# Altium schematic sheet Carte_Batterie PAMI 2024.SchDoc("Carte_Batterie PAMI 2024")
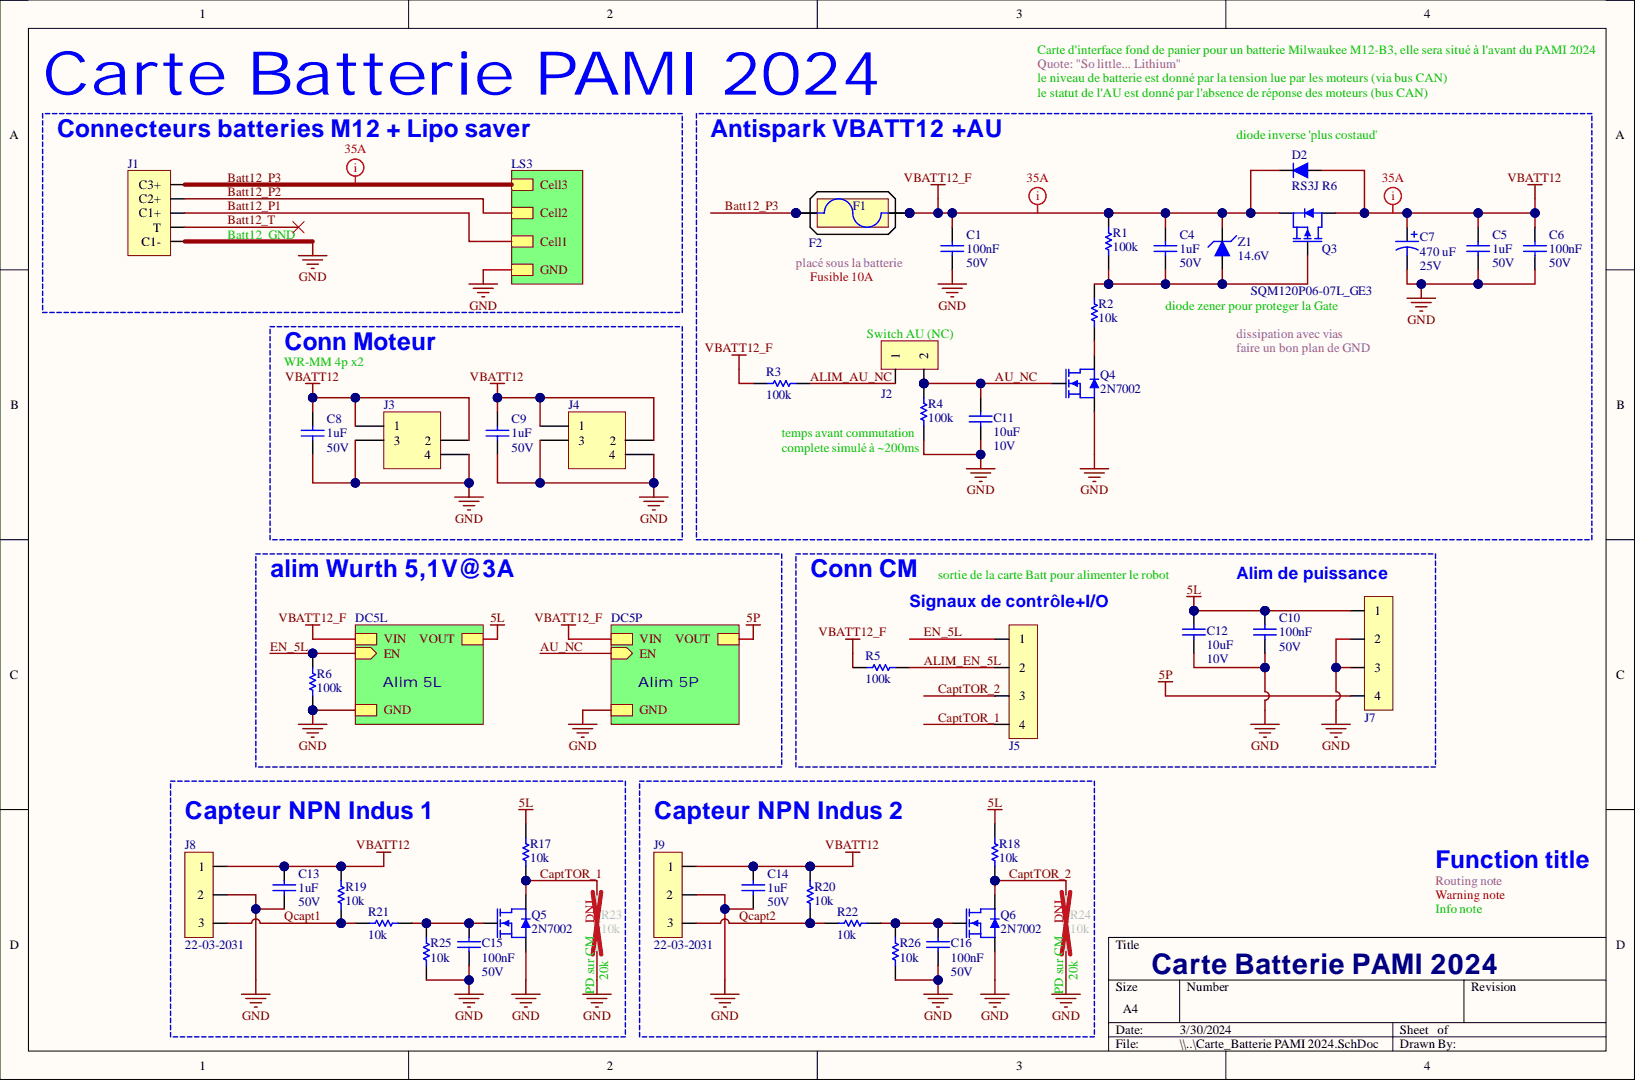
[diagram p0: Carte_Batterie PAMI 2024.SchDoc]

## Parts
C1  100nF  RatedVoltage: 50V  [WCAP-CSGP_0603, 1.6x0.8x0.8]
C4  1uF  RatedVoltage: 50V  [WCAP-CSGP_0805, 2x1.25x1.25]
C5  1uF  RatedVoltage: 50V  [WCAP-CSGP_0805, 2x1.25x1.25]
C6  100nF  RatedVoltage: 50V  [WCAP-CSGP_0603, 1.6x0.8x0.8]
C7  470 uF  RatedVoltage: 25V  [WCAP-ATG8_8x11.5x3.5x0.5]
C8  1uF  RatedVoltage: 50V  [WCAP-CSGP_0805, 2x1.25x1.25]
C9  1uF  RatedVoltage: 50V  [WCAP-CSGP_0805, 2x1.25x1.25]
C10  100nF  RatedVoltage: 50V  [WCAP-CSGP_0603, 1.6x0.8x0.8]
C11  10uF  RatedVoltage: 10V  [WCAP-CSGP_0805, 2x1.25x1.25]
C12  10uF  RatedVoltage: 10V  [WCAP-CSGP_0805, 2x1.25x1.25]
C13  1uF  RatedVoltage: 50V  [WCAP-CSGP_0805, 2x1.25x1.25]
C14  1uF  RatedVoltage: 50V  [WCAP-CSGP_0805, 2x1.25x1.25]
C15  100nF  RatedVoltage: 50V  [WCAP-CSGP_0603, 1.6x0.8x0.8]
C16  100nF  RatedVoltage: 50V  [WCAP-CSGP_0603, 1.6x0.8x0.8]
D2  RS3J R6  [SMC, DO-214AB]
F1  0891030.NXS  (value not drawn)  (mechanical)
F2  3557-2  [3557-2]  (value not drawn)
J1  custom connector  [CONN_BATT-M12]  (value not drawn)
J2  22-03-2021  [Conn_22-03-2021]  (value not drawn)
J3  value 4  [690367280476]  (hidden comment: 690367280476)
J4  value 4  [690367280476]  (hidden comment: 690367280476)
J5  22-03-2041  [Conn_22-03-2041]  (value not drawn)
J7  22-03-2041  [Conn_22-03-2041]  (value not drawn)
J8  22-03-2031  [Conn_22-03-2031]
J9  22-03-2031  [Conn_22-03-2031]
Q3  SQM120P06-07L_GE3  [TO263AB, D2PAK]
Q4  2N7002  [SOT-23]
Q5  2N7002  [SOT-23]
Q6  2N7002  [SOT-23]
R1  value 100k  [RESC1608X06N]  (hidden comment: RC0603FR-07100KL)
R2  value 10k  [RESC1608X06N]  (hidden comment: RC0603FR-0710KL)
R3  value 100k  [RESC1608X06N]  (hidden comment: RC0603FR-07100KL)
R4  value 100k  [RESC1608X06N]  (hidden comment: RC0603FR-07100KL)
R5  value 100k  [RESC1608X06N]  (hidden comment: RC0603FR-07100KL)
R6  value 100k  [RESC1608X06N]  (hidden comment: RC0603FR-07100KL)
R17  value 10k  [RESC1608X06N]  (hidden comment: RC0603FR-0710KL)
R18  value 10k  [RESC1608X06N]  (hidden comment: RC0603FR-0710KL)
R19  value 10k  [RESC1608X06N]  (hidden comment: RC0603FR-0710KL)
R20  value 10k  [RESC1608X06N]  (hidden comment: RC0603FR-0710KL)
R21  value 10k  [RESC1608X06N]  (hidden comment: RC0603FR-0710KL)
R22  value 10k  [RESC1608X06N]  (hidden comment: RC0603FR-0710KL)
R23  value 10k  [RESC1608X06N]  (hidden comment: RC0603FR-0710KL)
R24  value 10k  [RESC1608X06N]  (hidden comment: RC0603FR-0710KL)
R25  value 10k  [RESC1608X06N]  (hidden comment: RC0603FR-0710KL)
R26  value 10k  [RESC1608X06N]  (hidden comment: RC0603FR-0710KL)
Z1  value 14.6V  [SOD-323]  (hidden comment: MM3Z15VST1G)

## Nets
5L: C10.2 C12.2 J7.1 R17.2 R18.2
5P: J7.4
GND: C1.1 C5.1 C6.1 C7.2 C8.1 C9.1 C10.1 C11.1 C12.1 C13.1 C14.1 C15.2 C16.2 J1.5(C1-) J3.3 J3.4 J4.3 J4.4 J7.2 J7.3 J8.2 J9.2 Q4.2 Q5.2 Q6.2 R4.1 R6.1 R23.1 R24.1 R25.1 R26.1
VBATT12: C5.2 C6.2 C7.1 C8.2 C9.2 C13.2 C14.2 D2.1 J3.1 J3.2 J4.1 J4.2 J8.1 J9.1 Q3.4 R19.2 R20.2
VBATT12_F: C1.2 C4.2 D2.2 F2.2 F2.4 Q3.3 R1.2 R3.1 R5.1 Z1.2
ALIM_AU_NC: J2.1 R3.2
ALIM_EN_5L: J5.2 R5.2
AU_NC: C11.2 J2.2 Q4.1 R4.2
Batt12_P1: J1.3(C1+)
Batt12_P2: J1.2(C2+)
Batt12_P3: F2.1 F2.3 J1.1(C3+)
Batt12_T: J1.4(T)
CaptTOR_1: J5.4 Q5.3 R17.1 R23.2
CaptTOR_2: J5.3 Q6.3 R18.1 R24.2
EN_5L: J5.1 R6.2
Qcapt1: J8.3 R19.1 R21.1
Qcapt2: J9.3 R20.1 R22.1
NetC4_1: C4.1 Q3.1 R1.1 R2.2 Z1.1
NetC15_1: C15.1 Q5.1 R21.2 R25.2
NetC16_1: C16.1 Q6.1 R22.2 R26.2
NetQ4_3: Q4.3 R2.1

## Library data of the parts
C1 C6 C10 C15 C16 = WCAP-CSGP, 885012206095: CAP CER 100nF 50V X7R 0603; Manufacturer: Wurth Elektronik; CeramicType: X7R; Component Kind: Standard; ComponentLink1Description: Datasheet; ComponentLink1URL: http://katalog.we-online.com/pbs/datasheet/885012206095.pdf; Manufacturer Part Number: 885012206095; Size: 0603; Supplier 2: JLCPCB; Supplier Footprint 2: 0603; Supplier Part Number 2: C14663; Value: 100nF; Library Name: Condensateur.IntLib
C4 C5 C8 C9 C13 C14 = WCAP-CSGP, 885012207103: CAP CER 1uF 50V X7R 0805; Manufacturer: Wurth Elektronik; CeramicType: X7R; Component Kind: Standard; ComponentLink1Description: Datasheet; ComponentLink1URL: http://katalog.we-online.com/pbs/datasheet/885012207103.pdf; Manufacturer Part Number: 885012207103; Size: 0805; Supplier 2: JLCPCB; Supplier Footprint 2: 0805; Supplier Part Number 2: C28323; Value: 1uF; Library Name: Condensateur.IntLib
C7 = WCAP-ATG8, 860010474013: CAP Alu 470 UF 20% 25 V; Manufacturer: Wurth Elektronik; Component Kind: Standard; ComponentLink1Description: Datasheet; ComponentLink1URL: http://katalog.we-online.de/pbs/datasheet/860010474013.pdf; Manufacturer Part Number: 860010474013; Size: 8mmx11.5mm; Value: 470 uF; Library Name: Condensateur.IntLib
C11 C12 = WCAP-CSGP, 885012207026: CAP CER 10uF 10V X7R 0805; Manufacturer: Wurth Elektronik; CeramicType: X7R; Component Kind: Standard; ComponentLink1Description: Datasheet; ComponentLink1URL: http://katalog.we-online.com/pbs/datasheet/885012207026.pdf; Manufacturer Part Number: 885012207026; Size: 0805; Supplier 1: Farnell; Supplier 2: JLCPCB; Supplier Footprint 2: 0805; Supplier Part Number 1: 2495189; Supplier Part Number 2: C15850; Value: 10uF; Library Name: Condensateur.IntLib
D2 = RS3J_R6: DIODE GEN PURP 600V 3A SMC; Manufacturer: TAIWAN SEMICONDUCTOR; Type: Standard; Component Kind: Standard; ComponentLink1Description: Datasheet; ComponentLink1URL: http://www.farnell.com/datasheets/2182655.pdf; ContinuousForwardCurrent: 3A; Library Name: Diode.IntLib; Manufacturer Part Number: RS3J R6; Supplier 1: Farnell; Supplier Part Number 1: 2677388; Value: 600V
F1 = 0891030.NXS: FUSE AUTO 30A 58VDC BLADE MINI; Manufacturer: Littelfuse Inc.; ComponentLink1Description: Datasheet; ComponentLink1URL: http://www.littelfuse.com/~/media/automotive/datasheets/fuses/passenger-car-and-commercial-vehicle/blade-fuses/littelfuse_lowprofilemini_datasheet.pdf; Library Name: Fuse.IntLib; Manufacturer Part Number: 0891030.NXS; Supplier 1: Digi-Key; Supplier Part Number 1: F4993-ND; Value: 30A
F2 = 3557-2: FUSE Holder 500V 30A PCB; Manufacturer: Keystone Electronics; Value: na; Component Kind: Standard; ComponentLink1Description: Datasheet; ComponentLink1URL: http://www.keyelco.com/product-pdf.cfm?p=296; Library Name: Fuse.IntLib; Manufacturer Part Number: 3557-2; Supplier 1: Farnell; Supplier Part Number 1: 2292904
J1 = CONN_BATT-M12: Batterie connector for Milwaukee M12, use 2x RS 125-2006 and 3x RS 125-2000; Component Kind: Standard; Library Name: Divers.IntLib
J2 = 22-03-2021: CONN HEADER VERT 2POS 2.54MM; Manufacturer: MOLEX; Component Kind: Standard; ComponentLink1Description: Datasheet; ComponentLink1URL: http://www.farnell.com/datasheets/1791308.pdf; ComponentLink2Description: Drawings; ComponentLink2URL: http://www.farnell.com/cad/495071.pdf  http://www.farnell.com/cad/1533907.pdf  http://www.farnell.com/cad/1533907.pdf; Manufacturer Part Number: 22-03-2021; Supplier 1: Farnell; Supplier Part Number 1: 9731075; Library Name: Connecteurs.IntLib
J3 J4 = 690367280476: WR-MM 4p Female SMT Connector with Polarization; Manufacturer: Wurth Electronics Inc.; Component Kind: Standard; ComponentLink1Description: Datasheet; ComponentLink1URL: http://katalog.we-online.de/em/datasheet/69036728xx76.pdf; Manufacturer Part Number: 690367280476; Supplier 1: Farnell; Supplier Part Number 1: 1641848; Library Name: Connecteurs.IntLib
J5 J7 = Conn_22-03-2041: CONN HEADER VERT 4POS 2.54MM; Manufacturer: MOLEX; Component Kind: Standard; ComponentLink1Description: Datasheet; ComponentLink1URL: https://www.molex.com/pdm_docs/sd/022032041_sd.pdf; Manufacturer Part Number: 22-03-2041; Supplier 1: Farnell; Supplier Part Number 1: 9731091; Library Name: Connecteurs.IntLib
J8 J9 = 22-03-2031: CONN HEADER VERT 3POS 2.54MM; Manufacturer: MOLEX; Component Kind: Standard; ComponentLink1Description: Datasheet; ComponentLink1URL: http://www.farnell.com/datasheets/1785732.pdf; ComponentLink2Description: Drawings; ComponentLink2URL: http://www.farnell.com/cad/495071.pdf  http://www.farnell.com/cad/1533907.pdf  http://www.farnell.com/cad/1533907.pdf; Manufacturer Part Number: 22-03-2031; Supplier 1: Farnell; Supplier Part Number 1: 9731083; Library Name: Connecteurs.IntLib
Q3 = SQM120P06-07L_GE3: MOSFET P 60V 120A D2PAK; Manufacturer: VISHAY; Component Kind: Standard; ComponentLink1Description: Datasheet; ComponentLink1URL: http://www.vishay.com/docs/67026/sqm120p0.pdf; Library Name: Transistors.IntLib; Manufacturer Part Number: SQM120P06-07L_GE3; Supplier 1: Farnell; Supplier Part Number 1: 2617099
Q4-Q6 = 2N7002: MOSFET N 60V 115MA SOT23; Manufacturer: Changjiang Electronics Tech (CJ); Component Kind: Standard; ComponentLink1Description: Datasheet; ComponentLink1URL: https://datasheet.lcsc.com/szlcsc/1810151612_Changjiang-Electronics-Tech-CJ-2N7002_C8545.pdf; Library Name: Transistors.IntLib; Manufacturer Part Number: 2N7002; Supplier 1: Farnell; Supplier 2: JLCPCB; Supplier Footprint 2: SOT-23-3; Supplier Part Number 1: 1510761; Supplier Part Number 2: C8545
R1 R3-R6 = RC0603FR-07100KL: RES SMD 100K OHM 1% 1/10W 0603; Manufacturer: Yageo; Power: 100mW; Vmax: 50V; Component Kind: Standard; ComponentLink1Description: Manufacturer; ComponentLink1URL: http://www.yageo.com/NewPortal/_en/index.jsp; ComponentLink2Description: Datasheet; ComponentLink2URL: http://www.farnell.com/datasheets/2167052.pdf; Manufacturer Part Number: RC0603FR-07100KL; Precision: ±1%; Supplier 1: Farnell; Supplier 2: JLCPCB; Supplier Footprint 2: 0603; Supplier Part Number 1: 2309107; Supplier Part Number 2: C25803; Type: Thick Film; Library Name: Resistance.IntLib
R2 R17-R26 = RC0603FR-0710KL: RES SMD 10K OHM 1% 1/10W 0603; Manufacturer: Yageo; Power: 100mW; Vmax: 50V; Component Kind: Standard; ComponentLink1Description: Manufacturer; ComponentLink1URL: http://www.yageo.com/NewPortal/_en/index.jsp; ComponentLink2Description: Datasheet; ComponentLink2URL: http://www.farnell.com/datasheets/2167052.pdf; Manufacturer Part Number: RC0603FR-0710KL; Precision: ±1%; Supplier 1: Farnell; Supplier 2: JLCPCB; Supplier Footprint 2: 0603; Supplier Part Number 1: 9238603; Supplier Part Number 2: C25804; Type: Thick Film; Library Name: Resistance.IntLib
Z1 = MM3Z15VST1G: DIODE ZENER 14.6V 300mW SOD323; Manufacturer: ON Semiconductor; Component Kind: Standard; ComponentLink1Description: Datasheet; ComponentLink1URL: http://www.farnell.com/datasheets/2028649.pdf; Library Name: Diode.IntLib; Manufacturer Part Number: MM3Z15VST1G; Supplier 1: Farnell; Supplier Part Number 1: 2533369; Type: Zener
